# Altium schematic sheet Mainboard.SchDoc
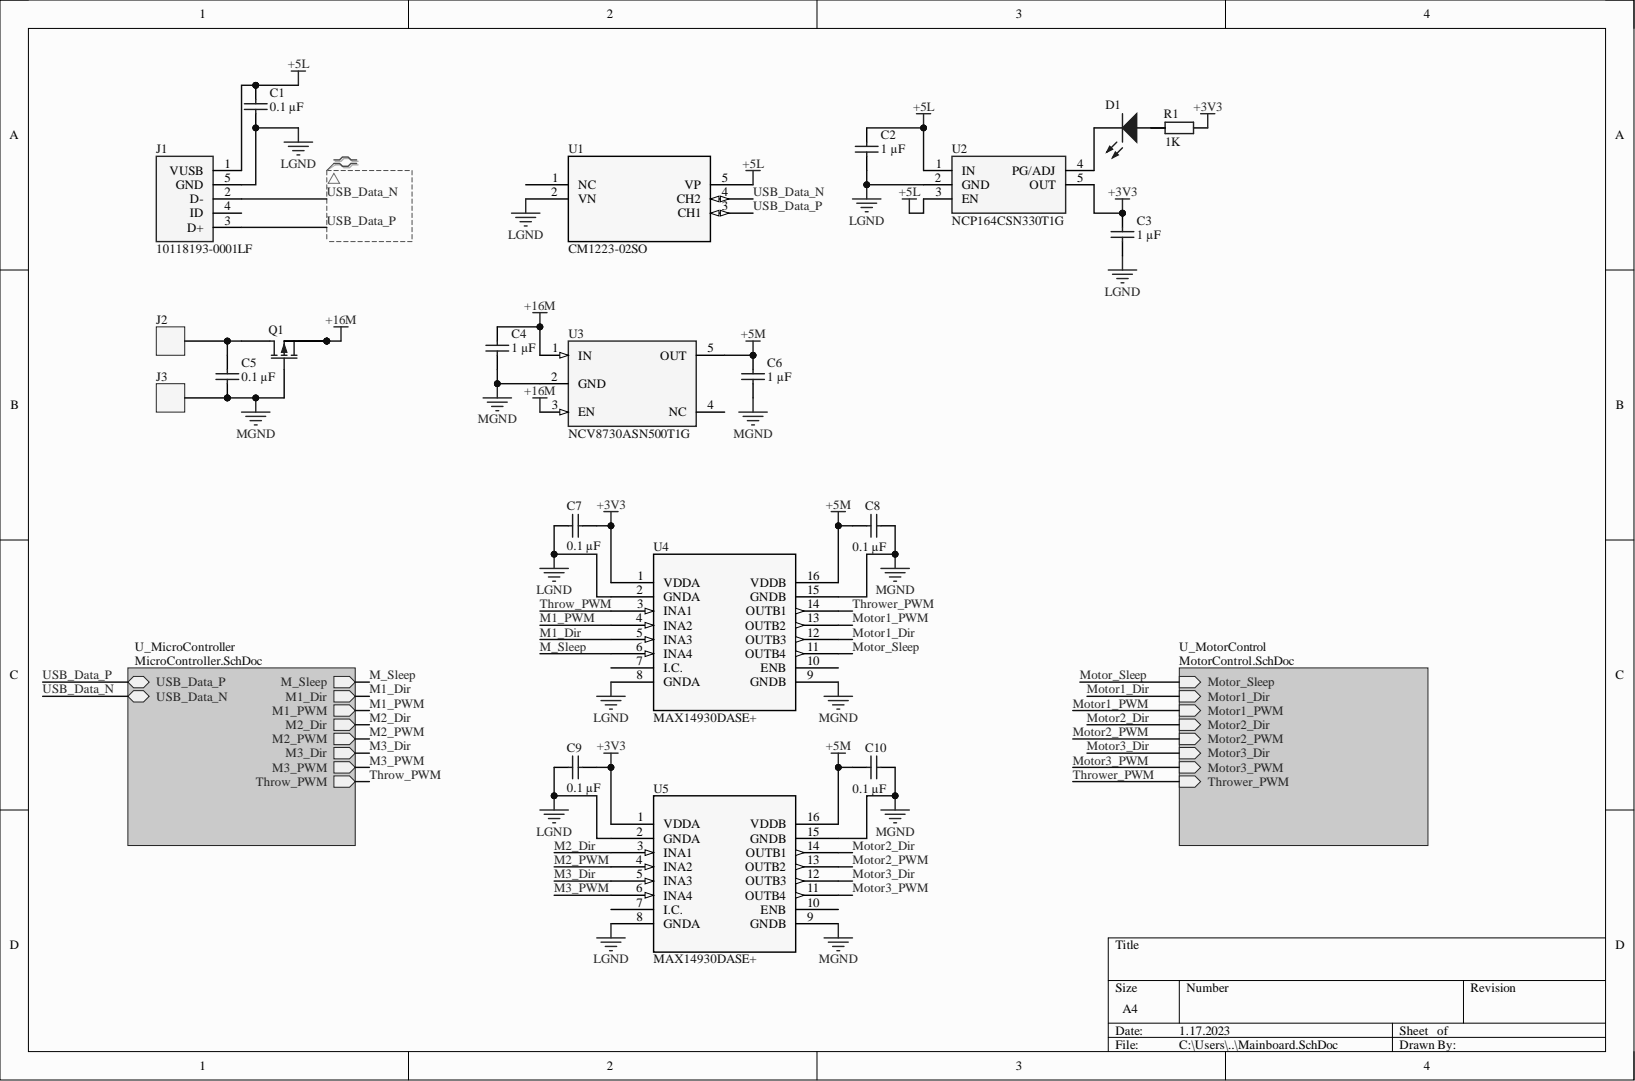
[diagram p0: Mainboard.SchDoc]

## Parts
C1  value 0.1 µF  [CAP_0603]  (hidden comment: MLCC)
C2  value 1 µF  [CAP_0603]  (hidden comment: MLCC)
C3  value 1 µF  [CAP_0603]  (hidden comment: MLCC)
C4  value 1 µF  [CAP_0603]  (hidden comment: MLCC)
C5  value 0.1 µF  [CAP_0603]  (hidden comment: MLCC)
C6  value 1 µF  [CAP_0603]  (hidden comment: MLCC)
C7  value 0.1 µF  [CAP_0603]  (hidden comment: MLCC)
C8  value 0.1 µF  [CAP_0603]  (hidden comment: MLCC)
C9  value 0.1 µF  [CAP_0603]  (hidden comment: MLCC)
C10  value 0.1 µF  [CAP_0603]  (hidden comment: MLCC)
D1  LED  [0603_GREEN]  (value not drawn)
J1  10118193-0001LF  [FCI_10118193-0001LF]
J2  Generic Power Pad  [New footprint 1]  (value not drawn)
J3  Generic Power Pad  [New footprint 1]  (value not drawn)
Q1  BUK6Y10-30P  [NXP-SOT669_N]  (value not drawn)
R1  value 1K  [RC0603N]  (hidden comment: RES)
U1  CM1223-02SO  [SOT23-5_3X1P7_ONS]
U2  NCP164CSN330T1G  [SOT95P275X110-5N]
U3  NCV8730ASN500T1G  [FP-483-MFG]
U4  MAX14930DASE+  [21-0041_S16+1_MXM]
U5  MAX14930DASE+  [21-0041_S16+1_MXM]

## Nets
+3V3: C3.2 C7.1 C9.1 R1.2 U2.5(OUT) U4.1(VDDA) U5.1(VDDA)
+5L: C1.2 C2.2 J1.1(VUSB) U1.5(VP) U2.1(IN) U2.3(EN)
+5M: C6.2 C8.2 C10.2 U3.5(OUT) U4.16(VDDB) U5.16(VDDB)
+16M: C4.2 Q1.1 Q1.2 Q1.3 U3.1(IN) U3.3(EN)
LGND: C1.1 C2.1 C3.1 C7.2 C9.2 J1.5(GND) U1.2(VN) U2.2(GND) U4.2(GNDA) U4.8(GNDA) U5.2(GNDA) U5.8(GNDA)
MGND: C4.1 C5.1 C6.1 C8.1 C10.1 J3.1 Q1.4 U3.2(GND) U4.9(GNDB) U4.15(GNDB) U5.9(GNDB) U5.15(GNDB)
M1_Dir: U4.5(INA3)
M1_PWM: U4.4(INA2)
M2_Dir: U5.3(INA1)
M2_PWM: U5.4(INA2)
M3_Dir: U5.5(INA3)
M3_PWM: U5.6(INA4)
M_Sleep: U4.6(INA4)
Motor1_Dir: U4.12(OUTB3)
Motor1_PWM: U4.13(OUTB2)
Motor2_Dir: U5.14(OUTB1)
Motor2_PWM: U5.13(OUTB2)
Motor3_Dir: U5.12(OUTB3)
Motor3_PWM: U5.11(OUTB4)
Motor_Sleep: U4.11(OUTB4)
Throw_PWM: U4.3(INA1)
Thrower_PWM: U4.14(OUTB1)
USB_Data_N: J1.2(D-) U1.4(CH2)
USB_Data_P: J1.3(D+) U1.3(CH1)
NetC5_2: C5.2 J2.1 Q1.5
NetD1_1: D1.1 R1.1
NetD1_2: D1.2 U2.4(PG/ADJ)
NetJ1_4: J1.4(ID)
NetU1_1: U1.1(NC)
NetU3_4: U3.4(NC)
NetU4_7: U4.7(I.C.)
NetU4_10: U4.10(ENB)
NetU5_7: U5.7(I.C.)
NetU5_10: U5.10(ENB)

## Library data of the parts
C1-C4 C6-C10 = CMP-059-000002-1: Multilayer Ceramic Capacitors; Tolerance: 10%; VoltageRatingDC: 5V; Component Kind: Standard; Component Type: Standard; Design Item ID: MLCC; Pin Count: 2; Source: Capacitors.SchLib; Library Name: Tartu University
C5 = CMP-059-000002-1: Multilayer Ceramic Capacitors; Tolerance: 10%; Value: 0.1 µF; VoltageRatingDC: 5V; Component Kind: Standard; Component Type: Standard; Design Item ID: MLCC; Pin Count: 2; Source: Capacitors.SchLib; Library Name: Tartu University
D1 = CMP-063-000000-1: LED Emitters; ForwardVoltage: 2V; Component Kind: Standard; Component Type: Standard; Design Item ID: LED; Pin Count: 2; Source: Leds.SchLib; Library Name: Tartu University
J1 = CMP-002-000000-4: USB - micro B USB 2.0 Receptacle Connector 5 Position Surface Mount, Right Angle; Through Hole; MF: Amphenol ICC; Availability: Unavailable; Component Kind: Standard; Component Type: Standard; Design Item ID: 10118193-0001LF; MP: 10118193-0001LF; Package: None; Pin Count: 11; Price: None; SnapEDA_Link: https://www.snapeda.com/parts/10118193-0001LF/Amphenol%20FCI/view-part/2758989/?ref=snap; Source: SnapEDAsch.SchLib; Library Name: Tartu University
J2 J3 = CMP-00002-4: Component Kind: Standard; Library Name: Tartu University
Q1 = CMP-011-000000-5: P-Channel Standard Level MOSFET SOT669-5; PartNumber: BUK7Y4R4-40EX; Automotive Qualified: Y; Channel Type: N; ID [max] (A): 100; IDM [max] (A): 521; Packing: Tape & Reel; Qr [typ] (nC): 18; VDS [max] (V): 40; VGSth [typ] (V): 3; Code_JEDEC: MO-235; Component Kind: Standard; Component Type: Transistor; Design Item ID: CMP-1259-00420-1; Mounting Technology: Surface Mount; PackageDescription: 4-Pin Plastic Single-ended Surface Mounted Package, Body 4.9 x 3.95 mm, Pitch 1.27 mm; PackageReference: SOT669; PackageVersion: 13-02-27, 02/2013; Pin Count: 5; Source: Components - 16.10.22 - 00.34.SchLib; Library Name: Tartu University
R1 = CMP-061-000001-5: Chip Resistors; PowerRating: 0.25W; Component Kind: Standard; Component Type: Standard; Design Item ID: RES; Pin Count: 2; Source: Resistors.SchLib; Library Name: Tartu University
U1 = CMP-044-000002-2: No Description Available; Mfr_Name: onsemi; Component Kind: Standard; Component Type: Standard; Design Item ID: CM1223-02SO; Manufacturer_Part_Number: CM1223-02SO; Pin Count: 5; RefDes: RefDes; Source: CM1223-02SO.SchLib; Type: DEV; Copyright: Copyright (C) 2022 Ultra Librarian. All rights reserved.; Library Name: Tartu University
U2 = NCP164CSN330T1G: Integrated Circuit; Manufacturer_Name: onsemi; Arrow Part Number: NCP164CSN330T1G; Arrow Price/Stock: https://www.arrow.com/en/products/ncp164csn330t1g/on-semiconductor?region=nac; Component Kind: Standard; Datasheet Link: https://componentsearchengine.com/Datasheets/2/NCP164CSN330T1G.pdf; Height: 1.1mm; Library Name: SamacSys.SchLib; Manufacturer_Part_Number: NCP164CSN330T1G; Mouser Part Number: 863-NCP164CSN330T1G; Mouser Price/Stock: https://www.mouser.co.uk/ProductDetail/onsemi/NCP164CSN330T1G?qs=xZ%2FP%252Ba9zWqbi%2FAyy5UtoRw%3D%3D
U3 = NCV8730ASN500T1G: LDO Regulator TSOP-5; Component Kind: Standard; Library Name: Components - 15.10.22 - 22.46.SchLib
U4 U5 = MAX14930DASE+: No Description Available; Mfr_Name: Maxim Integrated Products; Component Kind: Standard; Library Name: MAX14930DASE&plus_.SchLib; Manufacturer_Part_Number: MAX14930DASE+; RefDes: RefDes; Type: DEV; Copyright: Copyright (C) 2022 Ultra Librarian. All rights reserved.
